# Altium schematic sheet Volt_Measurement.SchDoc
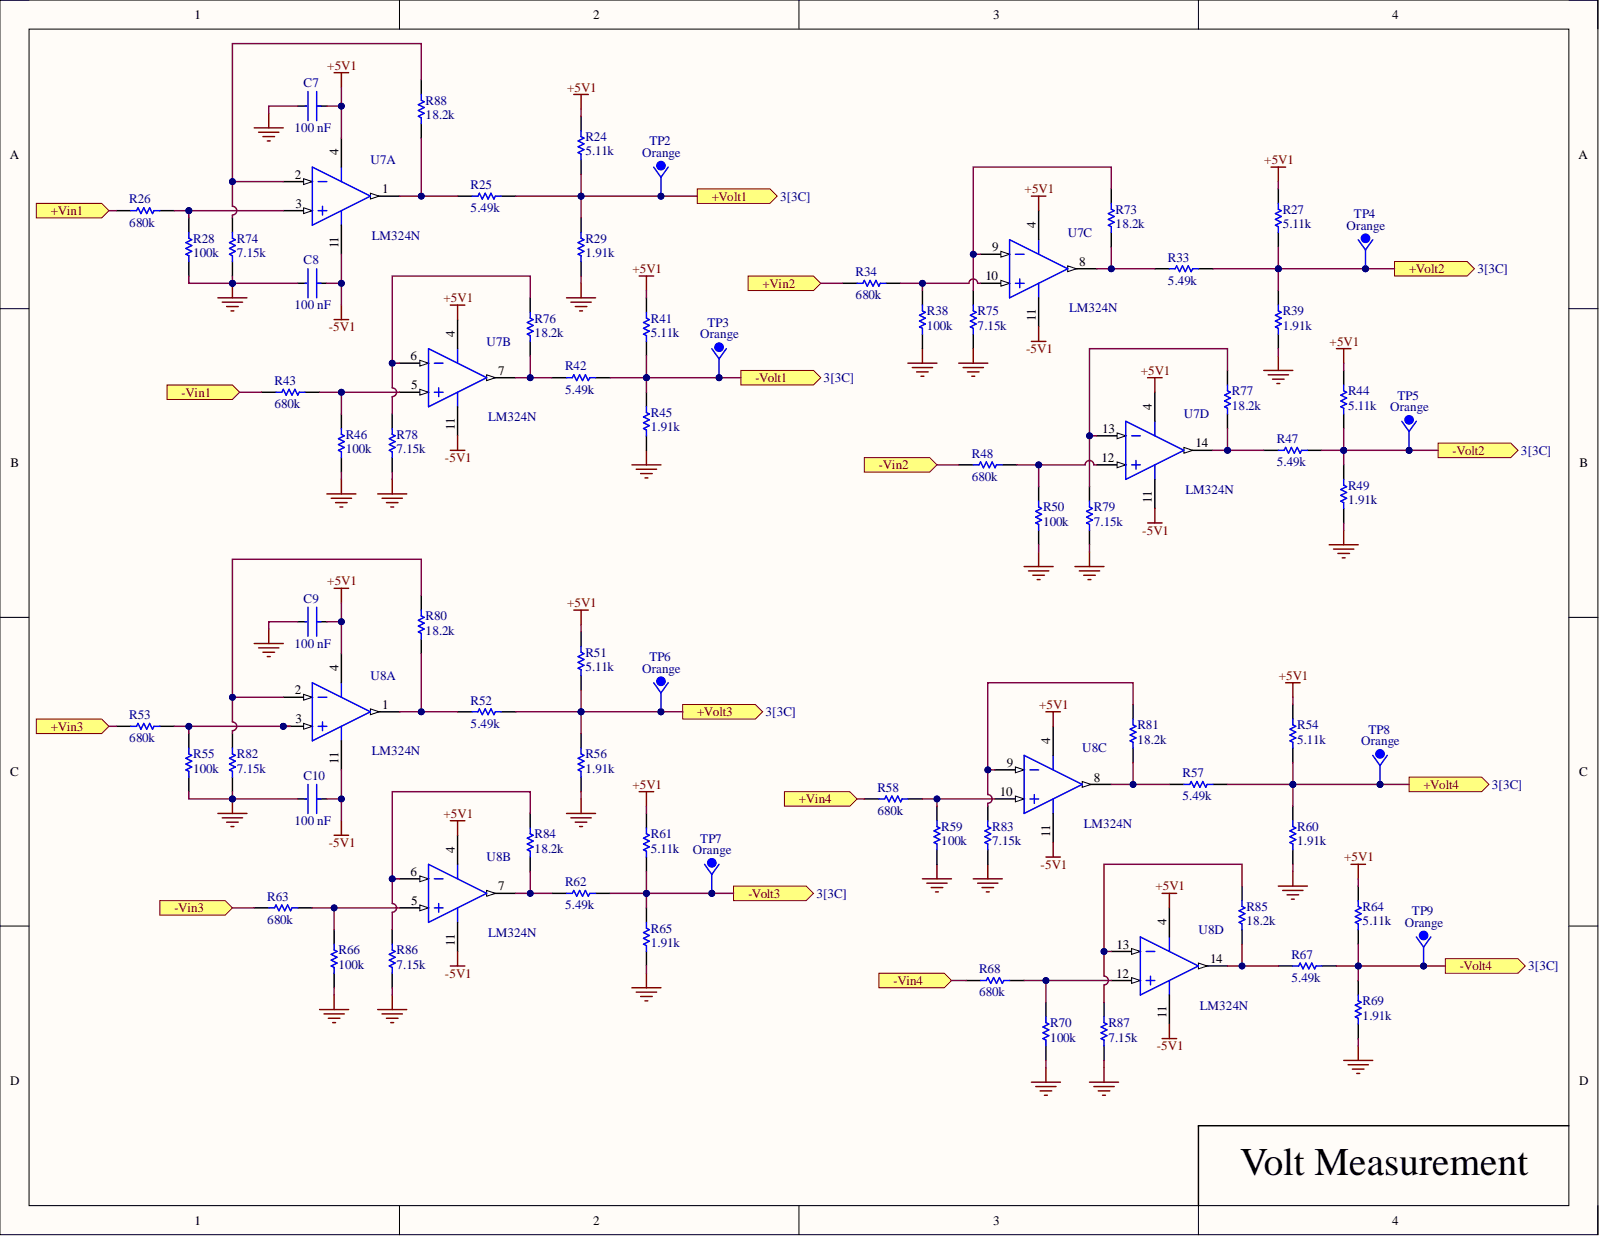
[diagram p0: Volt_Measurement.SchDoc]

## Parts
C7  100nF  value 100 nF  Capacitance: 100 nF  [FP-CC0805-0_85-MFG]
C8  100nF  value 100 nF  Capacitance: 100 nF  [FP-CC0805-0_85-MFG]
C9  100nF  value 100 nF  Capacitance: 100 nF  [FP-CC0805-0_85-MFG]
C10  100nF  value 100 nF  Capacitance: 100 nF  [FP-CC0805-0_85-MFG]
R24  value 5.11k  [0805 Res]  (hidden comment: 5.11k Ohm)
R25  value 5.49k  [0805 Res]  (hidden comment: 5.49k Ohm)
R26  value 680k  [0805 Res]  (hidden comment: 680k Ohm)
R27  value 5.11k  [0805 Res]  (hidden comment: 5.11k Ohm)
R28  value 100k  [0805 Res]  (hidden comment: 100k Ohm)
R29  value 1.91k  [0805 Res]  (hidden comment: 1.91k Ohm)
R33  value 5.49k  [0805 Res]  (hidden comment: 5.49k Ohm)
R34  value 680k  [0805 Res]  (hidden comment: 680k Ohm)
R38  value 100k  [0805 Res]  (hidden comment: 100k Ohm)
R39  value 1.91k  [0805 Res]  (hidden comment: 1.91k Ohm)
R41  value 5.11k  [0805 Res]  (hidden comment: 5.11k Ohm)
R42  value 5.49k  [0805 Res]  (hidden comment: 5.49k Ohm)
R43  value 680k  [0805 Res]  (hidden comment: 680k Ohm)
R44  value 5.11k  [0805 Res]  (hidden comment: 5.11k Ohm)
R45  value 1.91k  [0805 Res]  (hidden comment: 1.91k Ohm)
R46  value 100k  [0805 Res]  (hidden comment: 100k Ohm)
R47  value 5.49k  [0805 Res]  (hidden comment: 5.49k Ohm)
R48  value 680k  [0805 Res]  (hidden comment: 680k Ohm)
R49  value 1.91k  [0805 Res]  (hidden comment: 1.91k Ohm)
R50  value 100k  [0805 Res]  (hidden comment: 100k Ohm)
R51  value 5.11k  [0805 Res]  (hidden comment: 5.11k Ohm)
R52  value 5.49k  [0805 Res]  (hidden comment: 5.49k Ohm)
R53  value 680k  [0805 Res]  (hidden comment: 680k Ohm)
R54  value 5.11k  [0805 Res]  (hidden comment: 5.11k Ohm)
R55  value 100k  [0805 Res]  (hidden comment: 100k Ohm)
R56  value 1.91k  [0805 Res]  (hidden comment: 1.91k Ohm)
R57  value 5.49k  [0805 Res]  (hidden comment: 5.49k Ohm)
R58  value 680k  [0805 Res]  (hidden comment: 680k Ohm)
R59  value 100k  [0805 Res]  (hidden comment: 100k Ohm)
R60  value 1.91k  [0805 Res]  (hidden comment: 1.91k Ohm)
R61  value 5.11k  [0805 Res]  (hidden comment: 5.11k Ohm)
R62  value 5.49k  [0805 Res]  (hidden comment: 5.49k Ohm)
R63  value 680k  [0805 Res]  (hidden comment: 680k Ohm)
R64  value 5.11k  [0805 Res]  (hidden comment: 5.11k Ohm)
R65  value 1.91k  [0805 Res]  (hidden comment: 1.91k Ohm)
R66  value 100k  [0805 Res]  (hidden comment: 100k Ohm)
R67  value 5.49k  [0805 Res]  (hidden comment: 5.49k Ohm)
R68  value 680k  [0805 Res]  (hidden comment: 680k Ohm)
R69  value 1.91k  [0805 Res]  (hidden comment: 1.91k Ohm)
R70  value 100k  [0805 Res]  (hidden comment: 100k Ohm)
R73  value 18.2k  [0805 Res]  (hidden comment: 18.2k Ohm)
R74  value 7.15k  [0805 Res]  (hidden comment: 7.15k Ohm)
R75  value 7.15k  [0805 Res]  (hidden comment: 7.15k Ohm)
R76  value 18.2k  [0805 Res]  (hidden comment: 18.2k Ohm)
R77  value 18.2k  [0805 Res]  (hidden comment: 18.2k Ohm)
R78  value 7.15k  [0805 Res]  (hidden comment: 7.15k Ohm)
R79  value 7.15k  [0805 Res]  (hidden comment: 7.15k Ohm)
R80  value 18.2k  [0805 Res]  (hidden comment: 18.2k Ohm)
R81  value 18.2k  [0805 Res]  (hidden comment: 18.2k Ohm)
R82  value 7.15k  [0805 Res]  (hidden comment: 7.15k Ohm)
R83  value 7.15k  [0805 Res]  (hidden comment: 7.15k Ohm)
R84  value 18.2k  [0805 Res]  (hidden comment: 18.2k Ohm)
R85  value 18.2k  [0805 Res]  (hidden comment: 18.2k Ohm)
R86  value 7.15k  [0805 Res]  (hidden comment: 7.15k Ohm)
R87  value 7.15k  [0805 Res]  (hidden comment: 7.15k Ohm)
R88  value 18.2k  [0805 Res]  (hidden comment: 18.2k Ohm)
TP2  Color: Orange  [ORANGETP]  (hidden comment: TP (Orange))
TP3  Color: Orange  [ORANGETP]  (hidden comment: TP (Orange))
TP4  Color: Orange  [ORANGETP]  (hidden comment: TP (Orange))
TP5  Color: Orange  [ORANGETP]  (hidden comment: TP (Orange))
TP6  Color: Orange  [ORANGETP]  (hidden comment: TP (Orange))
TP7  Color: Orange  [ORANGETP]  (hidden comment: TP (Orange))
TP8  Color: Orange  [ORANGETP]  (hidden comment: TP (Orange))
TP9  Color: Orange  [ORANGETP]  (hidden comment: TP (Orange))
U7  Manufacturer Part Number: LM324N  [N0014A]  (hidden comment: 4CH Op-Amp)  (parts A,B,C,D)
U8  Manufacturer Part Number: LM324N  [N0014A]  (hidden comment: 4CH Op-Amp)  (parts A,B,C,D)

## Ports
+Vin1, +Vin2, +Vin3, +Vin4, +Volt1, +Volt2, +Volt3, +Volt4, -Vin1, -Vin2, -Vin3, -Vin4, -Volt1, -Volt2, -Volt3, -Volt4

## Nets
+5V1: C7.1 C9.1 R24.2 R27.2 R41.2 R44.2 R51.2 R54.2 R61.2 R64.2 U7.4 U8.4
-5V1: C8.1 C10.1 U7.11 U8.11
GND: C7.2 C8.2 C9.2 C10.2 R28.2 R29.1 R38.2 R39.1 R45.1 R46.2 R49.1 R50.2 R55.2 R56.1 R59.2 R60.1 R65.1 R66.2 R69.1 R70.2 R74.1 R75.1 R78.1 R79.1 R82.1 R83.1 R86.1 R87.1
NetR24_1: R24.1 R25.2 R29.2 TP2.1
NetR25_1: R25.1 R88.1 U7.1
NetR26_1: R26.1
NetR26_2: R26.2 R28.1 U7.3
NetR27_1: R27.1 R33.2 R39.2 TP4.1
NetR33_1: R33.1 R73.1 U7.8
NetR34_1: R34.1
NetR34_2: R34.2 R38.1 U7.10
NetR41_1: R41.1 R42.2 R45.2 TP3.1
NetR42_1: R42.1 R76.1 U7.7
NetR43_1: R43.1
NetR43_2: R43.2 R46.1 U7.5
NetR44_1: R44.1 R47.2 R49.2 TP5.1
NetR47_1: R47.1 R77.1 U7.14
NetR48_1: R48.1
NetR48_2: R48.2 R50.1 U7.12
NetR51_1: R51.1 R52.2 R56.2 TP6.1
NetR52_1: R52.1 R80.1 U8.1
NetR53_1: R53.1
NetR53_2: R53.2 R55.1 U8.3
NetR54_1: R54.1 R57.2 R60.2 TP8.1
NetR57_1: R57.1 R81.1 U8.8
NetR58_1: R58.1
NetR58_2: R58.2 R59.1 U8.10
NetR61_1: R61.1 R62.2 R65.2 TP7.1
NetR62_1: R62.1 R84.1 U8.7
NetR63_1: R63.1
NetR63_2: R63.2 R66.1 U8.5
NetR64_1: R64.1 R67.2 R69.2 TP9.1
NetR67_1: R67.1 R85.1 U8.14
NetR68_1: R68.1
NetR68_2: R68.2 R70.1 U8.12
NetR73_2: R73.2 R75.2 U7.9
NetR74_2: R74.2 R88.2 U7.2
NetR76_2: R76.2 R78.2 U7.6
NetR77_2: R77.2 R79.2 U7.13
NetR80_2: R80.2 R82.2 U8.2
NetR81_2: R81.2 R83.2 U8.9
NetR84_2: R84.2 R86.2 U8.6
NetR85_2: R85.2 R87.2 U8.13

## Library data of the parts
C7-C10 = CMP-1036-04402-6: CAP CER 0.1UF 16V X7R 0805; Manufacturer: Yageo; Manufacturer Part Number: CC0805KRX7R7BB104; Material: Ceramic; Tolerance: 0.1; Automotive: No; Category: Cap; Component Kind: Standard; ComponentLink2Description: Datasheet; ComponentLink2URL: http://www.yageo.com/documents/recent/UPY-GPHC_Y5V_6.3V-to-50V_8.pdf; Device Class L1: Passive Components; Device Class L2: Capacitors; Device Class L3: Ceramic Capacitors; Digikey Description: CAP CER 0.1UF 16V X7R 0805; Footprint Url: http://www.yageo.com/exep/pages/download/literatures/UPY-C_GEN_24.pdf; Height: 0.95mm; Ipc Land Pattern Name: CAPC200125X85; Lead Free: Yes; Library Name: Altium Content Vault; Mouser Description: Multilayer Ceramic Capacitors MLCC - SMD/SMT 100nF 16V X7R 10%; Octopart: https://octopart.com/cc0805krx7r7bb104-yageo-39467769; Package: CC0805-0.85; RoHS: TRUE; Temperature: -30°C to +85°C; Temperature Characteristic: X7R; Temperature Range High: +85°C; Temperature Range Low: -30°C; Voltage Rating: 16 V
R24 R27 R41 R44 R51 R54 R61 R64 = Res1: Fixed Resistor, Metal Glaze/thick Film, 0.125W, 5110ohm, 150V, 1% +/-Tol, 100ppm/Cel, Surface Mount, 0805; Manufacturer: Stackpole Electronics; Manufacturer Part Number: RMCF0805FT5K11; Package Height: 0.5mm; Package Length: 2mm; Package Width: 1.25mm; Power: 125mW; Rated Temperature: 70°C; Reference Standard: AEC-Q200; Temperature Coefficient (ppm/Cel): 100; Case/Package: 0805; Category: Chip SMD Resistors; Component Kind: Standard; ComponentLink1Description: https://datasheet.ciiva.com:443/pdfs/VipMasterIC/IC/SEIE/SEIE-S-A0009541969/SEIE-S-A0009541969-1.pdf?src-supplier=IHS+Markit; ComponentLink1URL: https://datasheet.ciiva.com:443/pdfs/VipMasterIC/IC/SEIE/SEIE-S-A0009541969/SEIE-S-A0009541969-1.pdf?src-supplier=IHS+Markit; ComponentLink2Description: https://datasheet.ciiva.com/15193/getdatasheetpartid-409010-15193607.pdf?src-supplier=Verical; ComponentLink2URL: https://datasheet.ciiva.com/15193/getdatasheetpartid-409010-15193607.pdf?src-supplier=Verical; Construction: Rectangular; JESD-609 Code: e3; LatestRevisionDate: 17-Jul-2002; LatestRevisionNote: Re-released for DXP Platform.; Library Name: Miscellaneous Devices.IntLib; Max Operating Temperature: 155°C; Min Operating Temperature: -55°C; Mounting Technology: Surface Mount; Package Shape: Rectangular; PackageDescription: Axial Device, Thru-Hole; 2 Leads; 0.3 in Pin Spacing; PackageReference: AXIAL-0.3; Packaging: Tape and Reel; Pins: 2; Pricing 1: 5000=0.00252, 10000=0.00248, 20000=0.00245, 30000=0.00241, 40000=0.00238 (USD); Published: 8-Jun-2000; Reach SVHC Compliant: Yes; Resistor Type: FIXED RESISTOR; RoHS: Yes; RoHS Compliant: Yes; Signal Integrity: Res; Simulation: RESISTOR; Stock 1: 240000; Supplier 1: Avnet; Supplier Part Number 1: RMCF0805FT5K11; Technology: METAL GLAZE/THICK FILM; Terminal Finish: Nickel; Termination: Wraparound; Tolerance: 1%; Working Voltage: 150V; Publisher: Altium Limited
R25 R33 R42 R47 R52 R57 R62 R67 = Res1: Fixed Resistor, Metal Glaze/thick Film, 0.125W, 5490ohm, 150V, 1% +/-Tol, 100ppm/Cel, Surface Mount, 0805; Manufacturer: Stackpole Electronics; Manufacturer Part Number: RMCF0805FT5K49; Package Height: 0.5mm; Package Length: 2mm; Package Width: 1.25mm; Power: 125mW; Rated Temperature: 70°C; Reference Standard: AEC-Q200; Temperature Coefficient (ppm/Cel): 100; Case/Package: 0805; Category: Chip SMD Resistors; Component Kind: Standard; ComponentLink1Description: https://datasheet.ciiva.com:443/pdfs/VipMasterIC/IC/SEIE/SEIE-S-A0009541969/SEIE-S-A0009541969-1.pdf?src-supplier=IHS+Markit; ComponentLink1URL: https://datasheet.ciiva.com:443/pdfs/VipMasterIC/IC/SEIE/SEIE-S-A0009541969/SEIE-S-A0009541969-1.pdf?src-supplier=IHS+Markit; ComponentLink2Description: https://www.seielect.com/catalog/sei-rmcf_rmcp.pdf?src-supplier=Digikey; ComponentLink2URL: https://www.seielect.com/catalog/sei-rmcf_rmcp.pdf?src-supplier=Digikey; ComponentLink3Description: https://www.seielect.com/catalog/SEI-RMCF_RMCP.pdf?src-supplier=Avnet; ComponentLink3URL: https://www.seielect.com/catalog/SEI-RMCF_RMCP.pdf?src-supplier=Avnet; Construction: Rectangular; JESD-609 Code: e3; LatestRevisionDate: 17-Jul-2002; LatestRevisionNote: Re-released for DXP Platform.; Library Name: Miscellaneous Devices.IntLib; Max Operating Temperature: 155°C; Min Operating Temperature: -55°C; Mounting Technology: Surface Mount; Package Shape: Rectangular; PackageDescription: Axial Device, Thru-Hole; 2 Leads; 0.3 in Pin Spacing; PackageReference: AXIAL-0.3; Packaging: Tape and Reel; Pins: 2; Pricing 1: 5000=0.00252, 10000=0.00248, 20000=0.00245, 30000=0.00241, 40000=0.00238 (USD); Published: 8-Jun-2000; Reach SVHC Compliant: Yes; Resistor Type: FIXED RESISTOR; RoHS: Yes; RoHS Compliant: Yes; Signal Integrity: Res; Simulation: RESISTOR; Stock 1: 50000; Supplier 1: Avnet; Supplier Part Number 1: RMCF0805FT5K49; Technology: METAL GLAZE/THICK FILM; Terminal Finish: Nickel; Termination: Wraparound; Tolerance: 1%; Working Voltage: 150V; Publisher: Altium Limited
R26 R34 R43 R48 R53 R58 R63 R68 = Res1: Fixed Resistor, Metal Glaze/thick Film, 0.125W, 680000ohm, 150V, 1% +/-Tol, 100ppm/Cel, Surface Mount, 0805; Manufacturer: Stackpole Electronics; Manufacturer Part Number: RMCF0805FT680K; Package Height: 0.5mm; Package Length: 2mm; Package Width: 1.25mm; Power: 125mW; Rated Temperature: 70°C; Reference Standard: AEC-Q200; Temperature Coefficient (ppm/Cel): 100; Case/Package: 0805; Category: Chip SMD Resistors; Component Kind: Standard; ComponentLink1Description: https://datasheet.ciiva.com:443/pdfs/VipMasterIC/IC/SEIE/SEIE-S-A0009541969/SEIE-S-A0009541969-1.pdf?src-supplier=IHS+Markit; ComponentLink1URL: https://datasheet.ciiva.com:443/pdfs/VipMasterIC/IC/SEIE/SEIE-S-A0009541969/SEIE-S-A0009541969-1.pdf?src-supplier=IHS+Markit; ComponentLink2Description: https://www.seielect.com/catalog/sei-packaging.pdf?src-supplier=Digikey; ComponentLink2URL: https://www.seielect.com/catalog/sei-packaging.pdf?src-supplier=Digikey; ComponentLink3Description: https://www.seielect.com/catalog/SEI-RMCF_RMCP.pdf?src-supplier=Avnet; ComponentLink3URL: https://www.seielect.com/catalog/SEI-RMCF_RMCP.pdf?src-supplier=Avnet; ComponentLink4Description: https://datasheet.octopart.com/RMCF0805FT680K-Stackpole-Electronics-datasheet-140082676.pdf?src-supplier=LKR; ComponentLink4URL: https://datasheet.octopart.com/RMCF0805FT680K-Stackpole-Electronics-datasheet-140082676.pdf?src-supplier=LKR; Construction: Rectangular; JESD-609 Code: e3; LatestRevisionDate: 17-Jul-2002; LatestRevisionNote: Re-released for DXP Platform.; Library Name: Miscellaneous Devices.IntLib; Max Operating Temperature: 155°C; Min Operating Temperature: -55°C; Mounting Technology: Surface Mount; Package Shape: Rectangular; PackageDescription: Axial Device, Thru-Hole; 2 Leads; 0.3 in Pin Spacing; PackageReference: AXIAL-0.3; Packaging: Tape and Reel; Pins: 2; Pricing 1: 5000=0.00252, 10000=0.00248, 20000=0.00245, 30000=0.00241, 40000=0.00238 (USD); Published: 8-Jun-2000; Reach SVHC Compliant: Yes; Resistor Type: FIXED RESISTOR; RoHS: Yes; RoHS Compliant: Yes; Signal Integrity: Res; Simulation: RESISTOR; Stock 1: 95000; Supplier 1: Avnet; Supplier Part Number 1: RMCF0805FT680K; Technology: METAL GLAZE/THICK FILM; Terminal Finish: Nickel; Termination: Wraparound; Tolerance: 1%; Working Voltage: 150V; Publisher: Altium Limited
R28 R38 R46 R50 R55 R59 R66 R70 = Res1: Fixed Resistor, Metal Glaze/thick Film, 0.125W, 100000ohm, 150V, 1% +/-Tol, 100ppm/Cel, Surface Mount, 0805; Manufacturer: Stackpole Electronics; Manufacturer Part Number: RMCF0805FT100K; Package Height: 0.5mm; Package Length: 2mm; Package Width: 1.25mm; Power: 125mW; Rated Temperature: 70°C; Reference Standard: AEC-Q200; Temperature Coefficient (ppm/Cel): 100; Case/Package: 0805; Category: Chip SMD Resistors; Component Kind: Standard; ComponentLink1Description: https://datasheet.ciiva.com:443/pdfs/VipMasterIC/IC/SEIE/SEIE-S-A0009541969/SEIE-S-A0009541969-1.pdf?src-supplier=IHS+Markit; ComponentLink1URL: https://datasheet.ciiva.com:443/pdfs/VipMasterIC/IC/SEIE/SEIE-S-A0009541969/SEIE-S-A0009541969-1.pdf?src-supplier=IHS+Markit; ComponentLink2Description: http://components.arrow.com/part/dataview/50568053S7005095N1174?src-supplier=Arrow+Electronics; ComponentLink2URL: http://components.arrow.com/part/dataview/50568053S7005095N1174?src-supplier=Arrow+Electronics; ComponentLink3Description: https://www.seielect.com/catalog/SEI-Packaging.pdf?src-supplier=Digikey; ComponentLink3URL: https://www.seielect.com/catalog/SEI-Packaging.pdf?src-supplier=Digikey; ComponentLink4Description: https://www.seielect.com/catalog/SEI-RMCF_RMCP.pdf?src-supplier=Avnet; ComponentLink4URL: https://www.seielect.com/catalog/SEI-RMCF_RMCP.pdf?src-supplier=Avnet; ComponentLink5Description: http://www.onlinecomponents.com/productFiles/mf-SEI/sei-rmcp.pdf?src-supplier=Onlinecomponents.com; ComponentLink5URL: http://www.onlinecomponents.com/productFiles/mf-SEI/sei-rmcp.pdf?src-supplier=Onlinecomponents.com; ComponentLink6Description: http://www.seielect.com/catalog/sei-rmc.pdf?src-supplier=Future+Electronics; ComponentLink6URL: http://www.seielect.com/catalog/sei-rmc.pdf?src-supplier=Future+Electronics; ComponentLink7Description: https://www.verical.com/server-webapp/html/getDatasheet?partID=360692&src-supplier=Verical; ComponentLink7URL: https://www.verical.com/server-webapp/html/getDatasheet?partID=360692&src-supplier=Verical; ComponentLink8Description: https://datasheet.octopart.com/RMCF0805FT100K-Stackpole-Electronics-datasheet-11547379.pdf?src-supplier=Future+Electronics; ComponentLink8URL: https://datasheet.octopart.com/RMCF0805FT100K-Stackpole-Electronics-datasheet-11547379.pdf?src-supplier=Future+Electronics; ComponentLink9Description: https://datasheet.octopart.com/RMCF0805FT100K-Stackpole-Electronics-datasheet-155966809.pdf?src-supplier=Electro+Sonic; ComponentLink9URL: https://datasheet.octopart.com/RMCF0805FT100K-Stackpole-Electronics-datasheet-155966809.pdf?src-supplier=Electro+Sonic; ComponentLink10Description: https://datasheet.octopart.com/RMCF0805FT100K-Stackpole-Electronics-datasheet-154799224.pdf?src-supplier=Master+Electronics; ComponentLink10URL: https://datasheet.octopart.com/RMCF0805FT100K-Stackpole-Electronics-datasheet-154799224.pdf?src-supplier=Master+Electronics; Construction: Rectangular; JESD-609 Code: e3; LatestRevisionDate: 17-Jul-2002; LatestRevisionNote: Re-released for DXP Platform.; Library Name: Miscellaneous Devices.IntLib; Max Operating Temperature: 155°C; Min Operating Temperature: -55°C; Mounting Technology: Surface Mount; Package Shape: Rectangular; PackageDescription: Axial Device, Thru-Hole; 2 Leads; 0.3 in Pin Spacing; PackageReference: AXIAL-0.3; Packaging: Tape and Reel; Pins: 2; Pricing 1: 5000=0.00252, 10000=0.00248, 20000=0.00245, 30000=0.00241, 40000=0.00238 (USD); Published: 8-Jun-2000; Reach SVHC Compliant: Yes; Resistor Type: FIXED RESISTOR; RoHS: Yes; RoHS Compliant: Yes; Signal Integrity: Res; Simulation: RESISTOR; Stock 1: 1060000; Supplier 1: Avnet; Supplier Part Number 1: RMCF0805FT100K; Technology: METAL GLAZE/THICK FILM; Terminal Finish: Nickel; Termination: Wraparound; Tolerance: 1%; Working Voltage: 150V; Publisher: Altium Limited
R29 R39 R45 R49 R56 R60 R65 R69 = Res1: Fixed Resistor, Metal Glaze/thick Film, 0.125W, 1910ohm, 150V, 1% +/-Tol, 100ppm/Cel, Surface Mount, 0805; Manufacturer: Stackpole Electronics; Manufacturer Part Number: RMCF0805FT1K91; Package Height: 0.5mm; Package Length: 2mm; Package Width: 1.25mm; Power: 125mW; Rated Temperature: 70°C; Reference Standard: AEC-Q200; Temperature Coefficient (ppm/Cel): 100; Case/Package: 0805; Category: Chip SMD Resistors; Component Kind: Standard; ComponentLink1Description: https://datasheet.ciiva.com:443/pdfs/VipMasterIC/IC/SEIE/SEIE-S-A0009541969/SEIE-S-A0009541969-1.pdf?src-supplier=IHS+Markit; ComponentLink1URL: https://datasheet.ciiva.com:443/pdfs/VipMasterIC/IC/SEIE/SEIE-S-A0009541969/SEIE-S-A0009541969-1.pdf?src-supplier=IHS+Markit; ComponentLink2Description: https://www.seielect.com/catalog/sei-rmcf_rmcp.pdf?src-supplier=Digikey; ComponentLink2URL: https://www.seielect.com/catalog/sei-rmcf_rmcp.pdf?src-supplier=Digikey; ComponentLink3Description: https://www.seielect.com/catalog/SEI-Packaging.pdf?src-supplier=Digikey; ComponentLink3URL: https://www.seielect.com/catalog/SEI-Packaging.pdf?src-supplier=Digikey; ComponentLink4Description: http://www.seielect.com/Catalog/SEI-RMCF_RMCP.pdf?src-supplier=Digikey; ComponentLink4URL: http://www.seielect.com/Catalog/SEI-RMCF_RMCP.pdf?src-supplier=Digikey; ComponentLink5Description: https://www.seielect.com/catalog/SEI-RMCF_RMCP.pdf?src-supplier=Avnet; ComponentLink5URL: https://www.seielect.com/catalog/SEI-RMCF_RMCP.pdf?src-supplier=Avnet; Construction: Rectangular; JESD-609 Code: e3; LatestRevisionDate: 17-Jul-2002; LatestRevisionNote: Re-released for DXP Platform.; Library Name: Miscellaneous Devices.IntLib; Max Operating Temperature: 155°C; Min Operating Temperature: -55°C; Mounting Technology: Surface Mount; Package Shape: Rectangular; PackageDescription: Axial Device, Thru-Hole; 2 Leads; 0.3 in Pin Spacing; PackageReference: AXIAL-0.3; Packaging: Tape and Reel; Pins: 2; Pricing 1: 5000=0.00252, 10000=0.00248, 20000=0.00245, 30000=0.00241, 40000=0.00238 (USD); Published: 8-Jun-2000; Reach SVHC Compliant: Yes; Resistor Type: FIXED RESISTOR; RoHS: Yes; RoHS Compliant: Yes; Signal Integrity: Res; Simulation: RESISTOR; Stock 1: 175000; Supplier 1: Avnet; Supplier Part Number 1: RMCF0805FT1K91; Technology: METAL GLAZE/THICK FILM; Terminal Finish: Nickel; Termination: Wraparound; Tolerance: 1%; Working Voltage: 150V; Publisher: Altium Limited
R73 R76 R77 R80 R81 R84 R85 R88 = Res1: Fixed Resistor, Metal Glaze/thick Film, 0.125W, 18200ohm, 150V, 1% +/-Tol, 100ppm/Cel, Surface Mount, 0805; Manufacturer: Stackpole Electronics; Manufacturer Part Number: RMCF0805FT18K2; Package Height: 0.5mm; Package Length: 2mm; Package Width: 1.25mm; Power: 125mW; Rated Temperature: 70°C; Reference Standard: AEC-Q200; Temperature Coefficient (ppm/Cel): 100; Case/Package: 0805; Category: Chip SMD Resistors; Component Kind: Standard; ComponentLink1Description: https://datasheet.ciiva.com:443/pdfs/VipMasterIC/IC/SEIE/SEIE-S-A0009541969/SEIE-S-A0009541969-1.pdf?src-supplier=IHS+Markit; ComponentLink1URL: https://datasheet.ciiva.com:443/pdfs/VipMasterIC/IC/SEIE/SEIE-S-A0009541969/SEIE-S-A0009541969-1.pdf?src-supplier=IHS+Markit; ComponentLink2Description: http://components.arrow.com/part/dataview/40964083S7077184N1174?src-supplier=Arrow+Electronics; ComponentLink2URL: http://components.arrow.com/part/dataview/40964083S7077184N1174?src-supplier=Arrow+Electronics; ComponentLink3Description: https://www.seielect.com/catalog/SEI-RMCF_RMCP.pdf?src-supplier=Avnet; ComponentLink3URL: https://www.seielect.com/catalog/SEI-RMCF_RMCP.pdf?src-supplier=Avnet; ComponentLink4Description: https://www.seielect.com/catalog/sei-rmcf_rmcp.pdf?src-supplier=Digikey; ComponentLink4URL: https://www.seielect.com/catalog/sei-rmcf_rmcp.pdf?src-supplier=Digikey; Construction: Rectangular; JESD-609 Code: e3; LatestRevisionDate: 17-Jul-2002; LatestRevisionNote: Re-released for DXP Platform.; Library Name: Miscellaneous Devices.IntLib; Max Operating Temperature: 155°C; Min Operating Temperature: -55°C; Mounting Technology: Surface Mount; Package Shape: Rectangular; PackageDescription: Axial Device, Thru-Hole; 2 Leads; 0.3 in Pin Spacing; PackageReference: AXIAL-0.3; Packaging: Tape and Reel; Pins: 2; Pricing 1: 5000=0.00252, 10000=0.00248, 20000=0.00245, 30000=0.00241, 40000=0.00238 (USD); Published: 8-Jun-2000; Reach SVHC Compliant: Yes; Resistor Type: FIXED RESISTOR; RoHS: Yes; RoHS Compliant: Yes; Signal Integrity: Res; Simulation: RESISTOR; Stock 1: 90000; Supplier 1: Avnet; Supplier Part Number 1: RMCF0805FT18K2; Technology: METAL GLAZE/THICK FILM; Terminal Finish: Nickel; Termination: Wraparound; Tolerance: 1%; Working Voltage: 150V; Publisher: Altium Limited
R74 R75 R78 R79 R82 R83 R86 R87 = Res1: Fixed Resistor, Metal Glaze/thick Film, 0.125W, 7150ohm, 150V, 1% +/-Tol, 100ppm/Cel, Surface Mount, 0805; Manufacturer: Stackpole Electronics; Manufacturer Part Number: RMCF0805FT7K15; Package Height: 0.5mm; Package Length: 2mm; Package Width: 1.25mm; Power: 125mW; Rated Temperature: 70°C; Reference Standard: AEC-Q200; Temperature Coefficient (ppm/Cel): 100; Case/Package: 0805; Category: Chip SMD Resistors; Component Kind: Standard; ComponentLink1Description: https://datasheet.ciiva.com:443/pdfs/VipMasterIC/IC/SEIE/SEIE-S-A0009541969/SEIE-S-A0009541969-1.pdf?src-supplier=IHS+Markit; ComponentLink1URL: https://datasheet.ciiva.com:443/pdfs/VipMasterIC/IC/SEIE/SEIE-S-A0009541969/SEIE-S-A0009541969-1.pdf?src-supplier=IHS+Markit; ComponentLink2Description: http://components.arrow.com/part/dataview/44987969S7384101N1174?src-supplier=Arrow+Electronics; ComponentLink2URL: http://components.arrow.com/part/dataview/44987969S7384101N1174?src-supplier=Arrow+Electronics; ComponentLink3Description: https://www.seielect.com/catalog/sei-rmcf_rmcp.pdf?src-supplier=Digikey; ComponentLink3URL: https://www.seielect.com/catalog/sei-rmcf_rmcp.pdf?src-supplier=Digikey; ComponentLink4Description: https://www.seielect.com/catalog/SEI-Packaging.pdf?src-supplier=Digikey; ComponentLink4URL: https://www.seielect.com/catalog/SEI-Packaging.pdf?src-supplier=Digikey; ComponentLink5Description: https://www.seielect.com/catalog/SEI-RMCF_RMCP.pdf?src-supplier=Avnet; ComponentLink5URL: https://www.seielect.com/catalog/SEI-RMCF_RMCP.pdf?src-supplier=Avnet; Construction: Rectangular; JESD-609 Code: e3; LatestRevisionDate: 17-Jul-2002; LatestRevisionNote: Re-released for DXP Platform.; Library Name: Miscellaneous Devices.IntLib; Max Operating Temperature: 155°C; Min Operating Temperature: -55°C; Mounting Technology: Surface Mount; Package Shape: Rectangular; PackageDescription: Axial Device, Thru-Hole; 2 Leads; 0.3 in Pin Spacing; PackageReference: AXIAL-0.3; Packaging: Tape and Reel; Pins: 2; Pricing 1: 5000=0.00252, 10000=0.00248, 20000=0.00245, 30000=0.00241, 40000=0.00238 (USD); Published: 8-Jun-2000; Reach SVHC Compliant: Yes; Resistor Type: FIXED RESISTOR; RoHS: Yes; RoHS Compliant: Yes; Signal Integrity: Res; Simulation: RESISTOR; Stock 1: 65000; Supplier 1: Avnet; Supplier Part Number 1: RMCF0805FT7K15; Technology: METAL GLAZE/THICK FILM; Terminal Finish: Nickel; Termination: Wraparound; Tolerance: 1%; Working Voltage: 150V; Publisher: Altium Limited
TP2-TP9 = TP: Interconnection Device; Manufacturer: Keystone; Manufacturer P/N: 5013; Manufacturer Part Number: 5003; Category: Connectors; Component Kind: Standard; ComponentLink1Description: https://datasheet.ciiva.com:443/pdfs/VipMasterIC/IC/KEYC/KEYCS01036/KEYCS02044-1.pdf?src-supplier=IHS+Markit; ComponentLink1URL: https://datasheet.ciiva.com:443/pdfs/VipMasterIC/IC/KEYC/KEYCS01036/KEYCS02044-1.pdf?src-supplier=IHS+Markit; ComponentLink2Description: https://datasheet.ciiva.com/22631/1703983-22631103.pdf?src-supplier=Element14; ComponentLink2URL: https://datasheet.ciiva.com/22631/1703983-22631103.pdf?src-supplier=Element14; ComponentLink3Description: https://datasheet.ciiva.com/4598/5000-5004-4598618.pdf?src-supplier=Mouser; ComponentLink3URL: https://datasheet.ciiva.com/4598/5000-5004-4598618.pdf?src-supplier=Mouser; ComponentLink4Description: https://datasheet.ciiva.com/16885/70182825-16885788.pdf?src-supplier=Allied+Electronics; ComponentLink4URL: https://datasheet.ciiva.com/16885/70182825-16885788.pdf?src-supplier=Allied+Electronics; ComponentLink5Description: https://datasheet.ciiva.com/1454/1703983-1454826.pdf?src-supplier=Element14; ComponentLink5URL: https://datasheet.ciiva.com/1454/1703983-1454826.pdf?src-supplier=Element14; Connector Type: Interconnection Device; Contact Finish - Termination: Silver (Ag); JESD-609 Code: e4; Pricing 1: 1=0.29, 10=0.29, 50=0.262, 100=0.222, 1000=0.173, 10000=0.143 (USD); Reach SVHC Compliant: Yes; RoHS: Yes; RoHS Compliant: Yes; Stock 1: 79700; Supplier 1: Mouser; Supplier Part Number 1: 534-5003
U7 U8 = CMP-0055-00082-3: Low Power Quad Operational Amplifier, 14-pin MDIP; Manufacturer: Texas Instruments; CompType: Amplifiers; PackageTopMarking: NSUZXYYTTE#*LM324N; PowerWise: N; Code_JEDEC: MS-001-AA; Component Kind: Standard; ComponentLink1Description: Datasheet URL; ComponentLink1URL: http://www.ti.com/general/docs/lit/getliterature.tsp?genericPartNumber=LM324&fileType=pdf; Library Name: Altium Content Vault; Package Reference: N0014A; PackageDescription: MDIP, .750 x .250 x.130in, 14 Lead, .100in Pitch; PinCount: 14; RoHS: N
